# Altium schematic sheet Filters.SchDoc(Filters)
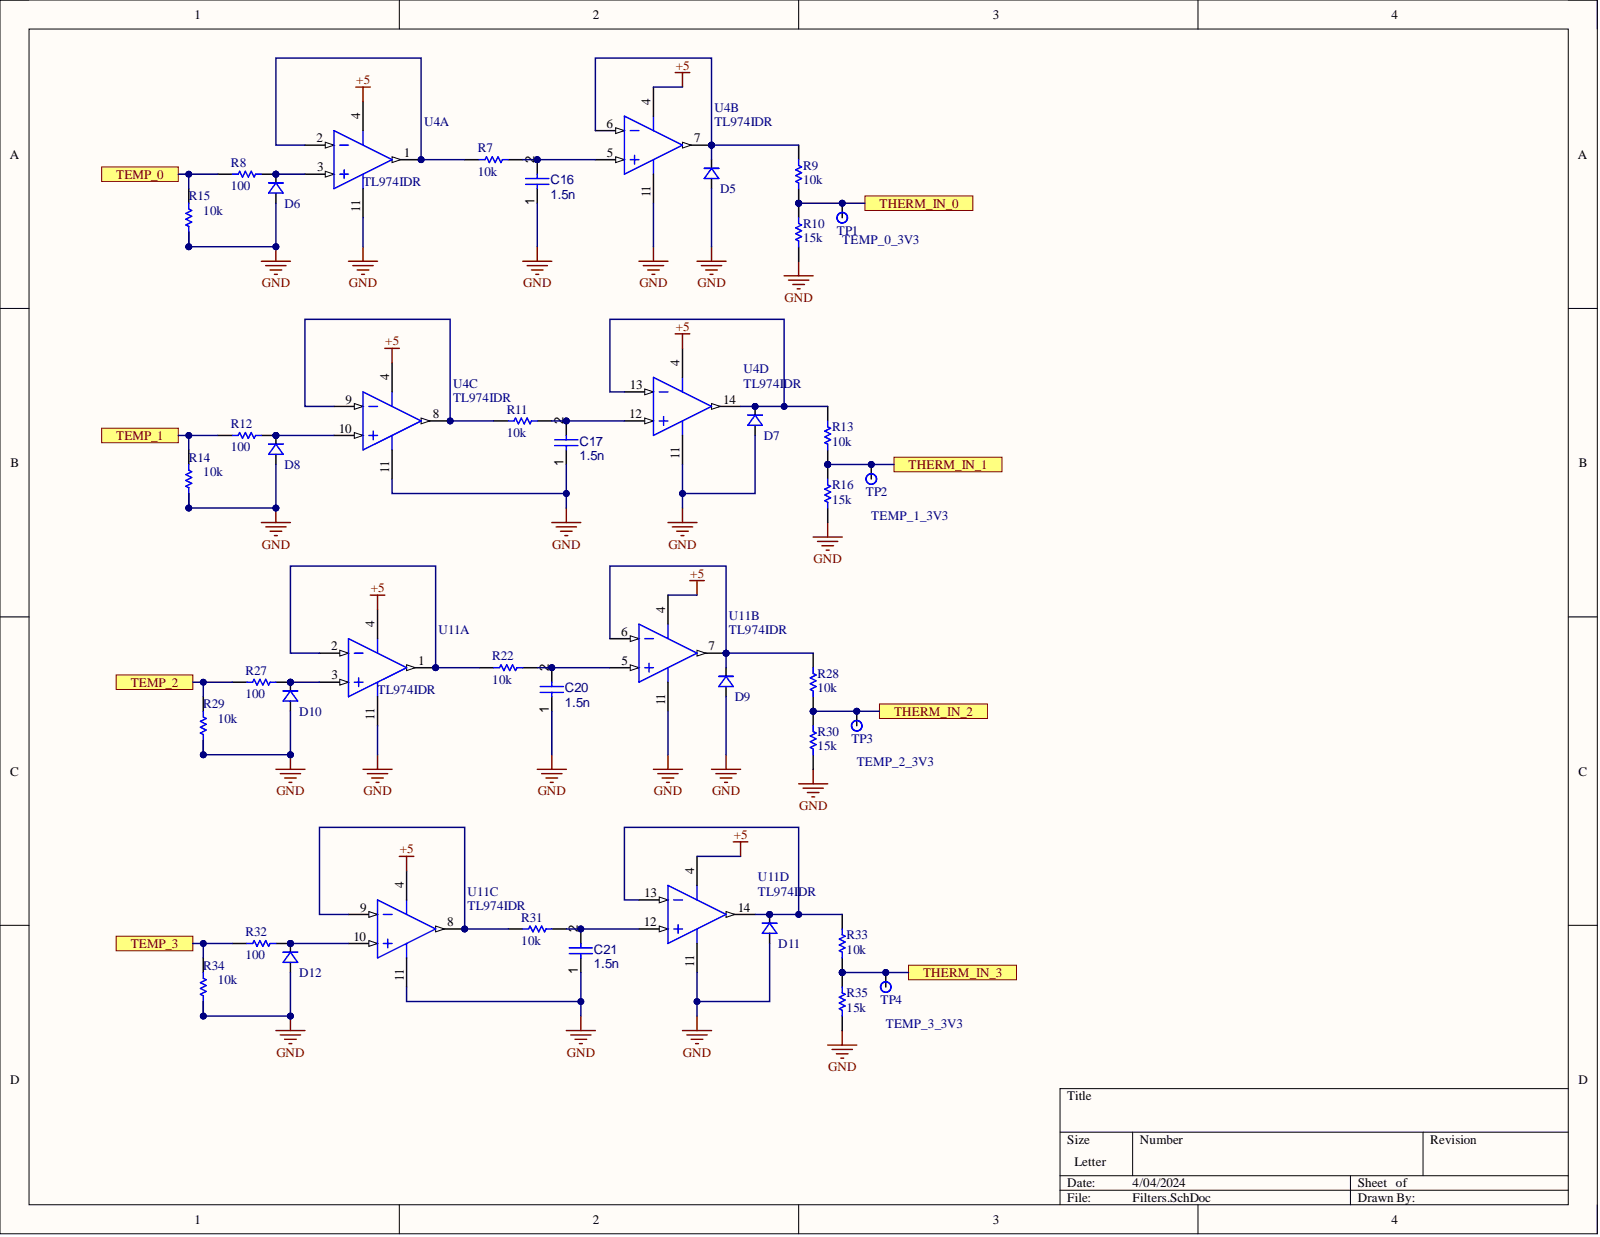
[diagram p0: Filters.SchDoc]

## Parts
C16  1.5n
C17  1.5n
C20  1.5n
C21  1.5n
D5  DIODE-SOD123  (value not drawn)
D6  DIODE-SOD123  (value not drawn)
D7  DIODE-SOD123  (value not drawn)
D8  DIODE-SOD123  (value not drawn)
D9  DIODE-SOD123  (value not drawn)
D10  DIODE-SOD123  (value not drawn)
D11  DIODE-SOD123  (value not drawn)
D12  DIODE-SOD123  (value not drawn)
R7  value 10k  (hidden comment: CMP-009-00006-4)
R8  value 100  (hidden comment: CMP-009-00006-4)
R9  value 10k  (hidden comment: CMP-009-00006-4)
R10  value 15k  (hidden comment: CMP-009-00006-4)
R11  value 10k  (hidden comment: CMP-009-00006-4)
R12  value 100  (hidden comment: CMP-009-00006-4)
R13  value 10k  (hidden comment: CMP-009-00006-4)
R14  value 10k  (hidden comment: CMP-009-00006-4)
R15  value 10k  (hidden comment: CMP-009-00006-4)
R16  value 15k  (hidden comment: CMP-009-00006-4)
R22  value 10k  (hidden comment: CMP-009-00006-4)
R27  value 100  (hidden comment: CMP-009-00006-4)
R28  value 10k  (hidden comment: CMP-009-00006-4)
R29  value 10k  (hidden comment: CMP-009-00006-4)
R30  value 15k  (hidden comment: CMP-009-00006-4)
R31  value 10k  (hidden comment: CMP-009-00006-4)
R32  value 100  (hidden comment: CMP-009-00006-4)
R33  value 10k  (hidden comment: CMP-009-00006-4)
R34  value 10k  (hidden comment: CMP-009-00006-4)
R35  value 15k  (hidden comment: CMP-009-00006-4)
TP1  TEMP_0_3V3
TP2  TEMP_1_3V3
TP3  TEMP_2_3V3
TP4  TEMP_3_3V3
U4  TL974IDR  (parts A,B,C,D)
U11  TL974IDR  (parts A,B,C,D)

## Ports
TEMP_0, TEMP_1, TEMP_2, TEMP_3, THERM_IN_0, THERM_IN_1, THERM_IN_2, THERM_IN_3

## Nets
+5: U4.4 U11.4
GND: C16.1 C17.1 C20.1 C21.1 D5.A D6.A D7.A D8.A D9.A D10.A D11.A D12.A R10.2 R14.2 R15.2 R16.2 R29.2 R30.2 R34.2 R35.2 U4.11 U11.11
TEMP_0: R8.1 R15.1 ; port TEMP_0
TEMP_1: R12.1 R14.1 ; port TEMP_1
TEMP_2: R27.1 R29.1 ; port TEMP_2
TEMP_3: R32.1 R34.1 ; port TEMP_3
THERM_IN_0: R9.2 R10.1 TP1.1 ; port THERM_IN_0
THERM_IN_1: R13.2 R16.1 TP2.1 ; port THERM_IN_1
THERM_IN_2: R28.2 R30.1 TP3.1 ; port THERM_IN_2
THERM_IN_3: R33.2 R35.1 TP4.1 ; port THERM_IN_3
NetC16_2: C16.2 R7.1 U4.5
NetC17_2: C17.2 R11.1 U4.12
NetC20_2: C20.2 R22.1 U11.5
NetC21_2: C21.2 R31.1 U11.12
NetD5_K: D5.K R9.1 U4.6 U4.7
NetD6_K: D6.K R8.2 U4.3
NetD7_K: D7.K R13.1 U4.13 U4.14
NetD8_K: D8.K R12.2 U4.10
NetD9_K: D9.K R28.1 U11.6 U11.7
NetD10_K: D10.K R27.2 U11.3
NetD11_K: D11.K R33.1 U11.13 U11.14
NetD12_K: D12.K R32.2 U11.10
NetR7_2: R7.2 U4.1 U4.2
NetR11_2: R11.2 U4.8 U4.9
NetR22_2: R22.2 U11.1 U11.2
NetR31_2: R31.2 U11.8 U11.9

## Library data of the parts
C16 C17 C20 C21 = CMP-005-000616-1: Chip Capacitor, 2,2µF +/-20%, 10V, 0402, Thickness 0.6 mm; Available Preview Images: 2D; Component Kind: Standard; Design Item ID: CMP-001-00056-2; Source: Integrated_Library - 12.03.21 - 15.39.SchLib; Library Name: Illini Formula Electric
D5-D12 = CMP-010-000008-1: Component Kind: Standard; Design Item ID: DIODE-SOD123; Library Name: Illini Formula Electric; Source: ife19_Elec_Library.SchLib
R7-R16 R22 R27-R35 = CMP-009-000010-1: Chip Resistor, 0 Ohm, 0.1 W, -55 to 155 degC, 0603 (1608 Metric); Component Kind: Standard; Design Item ID: CMP-009-00006-4; Source: Integrated_Library - 12.03.21 - 15.39.SchLib; Library Name: Illini Formula Electric
TP1-TP4 = CMP-009-000182-1: Component Kind: Standard; Design Item ID: Testpoint; Library Name: Illini Formula Electric; Source: ife19_Elec_Library.SchLib
U4 U11 = CMP-0015-00021-2: Output Rail-To-Rail Very-Low-Noise Operational Amplifier, 2.7 to 12 V, -40 to 125 degC, 14-pin SOIC (D14), Green (RoHS & no Sb/Br); Manufacturer: Texas Instruments; CMRR(Min)(dB): 60; GBW(Typ)(MHz): 12; IIB(Max)(pA): 750000; Iq per channel(Max)(mA): 2.8; Number of Channels: 4; Offset Drift(Typ)(uV/C): 5; Rating: Catalog; Sub Family: General Purpose Amplifier, Low Supply Voltage Amplifier (<=2.7V); Total Supply Voltage(Min)(+5V is 5, +/-5V is 10): 2.7; Code_JEDEC: MS-012; Component Kind: Standard; ComponentLink1Description: Manufacturer URL; ComponentLink1URL: http://www.ti.com/; ComponentLink2Description: Datasheet; ComponentLink2URL: http://www.ti.com/lit/ds/symlink/tl974.pdf; ComponentLink3Description: Package Specification; ComponentLink3URL: http://www.ti.com/lit/ml/mpds177g/mpds177g.pdf; DatasheetVersion: SLOS467G; Eco-Plan: Green (RoHS & no Sb/Br); Library Name: Altium Content Vault; Mounting Technology: Surface Mount; PackageDescription: 14-Pin Plastic Small Outline, Body 8.65 x 3.90 mm, Pitch 1.27 mm; PackageReference: D0014A; PackageVersion: 4040047-5/M, 06/2011; PartNumber: TL974IDR; Slew Rate(Typ)(V/us): 5; Total Supply Voltage(Max)(+5V is 5, +/-5V is 10): 12; Vio (25C)(Max)(mV): 4
